# Altium schematic sheet R9M_BLUE.SchDoc
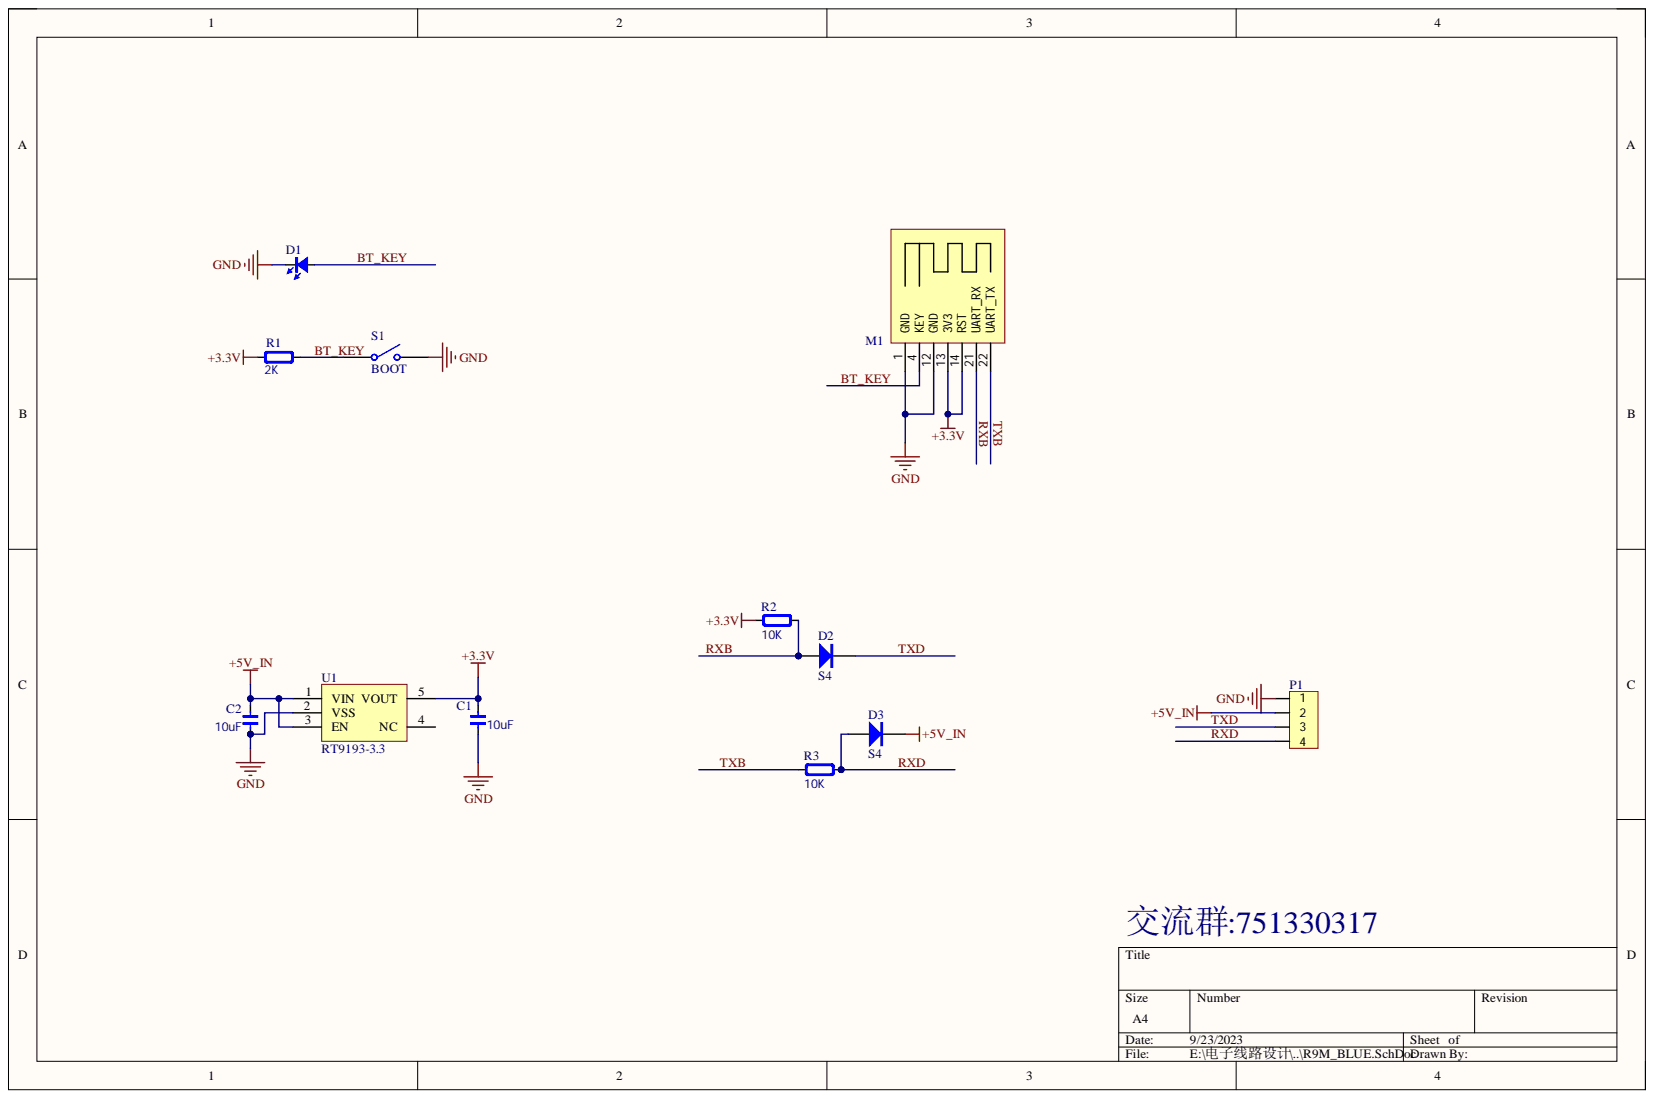
[diagram p0: R9M_BLUE.SchDoc]

## Parts
C1  10uF  [CAP_0603]
C2  10uF  [CAP_0603]
D1  D0603  [LED_0603_RED]  (value not drawn)
D2  S4  [SOD-323]
D3  S4  [SOD-323]
M1  SmartBLE  [BT04-E]  (value not drawn)
P1  HDR1x4  [HDR1X4_2.54_180F]  (value not drawn)
R1  2K  [RES_0402]
R2  10K  [RES_0402]
R3  10K  [RES_0402]
S1  BOOT  [ButtonTACT_3x4x2_180]
U1  RT9193-3.3  [SOT23-5]

## Nets
+3.3V: C1.2 M1.13(3V3) M1.14(RST) R1.2 R2.1 U1.5(VOUT)
+5V_IN: C2.2 D3.2 P1.2 U1.1(VIN) U1.3(EN)
GND: C1.1 C2.1 D1.2 M1.1(GND) M1.12(GND) P1.1 S1.2 U1.2(VSS)
BT_KEY: D1.1 M1.4(KEY) R1.1 S1.1
RXB: D2.1 M1.21(UART_RX) R2.2
RXD: D3.1 P1.4 R3.2
TXB: M1.22(UART_TX) R3.1
TXD: D2.2 P1.3

Not connected: U1 4(NC)

## Library data of the parts
C1 C2 = Cap_0402: Capacitor; Note: ±10%; Specification: X7R, ±10%, 16V; Type: 0402; Component Kind: Standard; Library Name: SCH_Passive.SchLib; Value: 10uF
D1 = LED_0603: LED; Type: 0603; Value: RED; Component Kind: Standard; Library Name: SCH_Active.SchLib
D2 D3 = 1N5819: 肖特基二极管; 属性: 40V/2A; 版权归属: 源创客; BOM名称: 肖特基二极管; Component Kind: Standard; Library Name: 8.1   - 二极管-整流桥.SchLib; 学习资源: www.ooocke.com
M1 = BT04-E: Module; Component Kind: Standard; Library Name: SCH_Module.SchLib
P1 = HDR1x4_2.54: Header; Value: 2.54; Component Kind: Standard; Library Name: SCH_ConnectorHDR.SchLib; Type: DIP 1x4
R1-R3 = Res_0402: Resistor; Note: ±1%; Value: 1K; Component Kind: Standard; Library Name: SCH_Passive.SchLib; Specification: ±1%; Type: 0402
S1 = SwitchSPST: Switch; Component Kind: Standard; Library Name: SCH_Switch.SchLib; Type: SPST 2P
U1 = SPX3819M5: Component Kind: Standard; Library Name: SCH_Power.SchLib
